# Altium schematic sheet Photodiode Receiver.SchDoc("Photodiode Receiver")
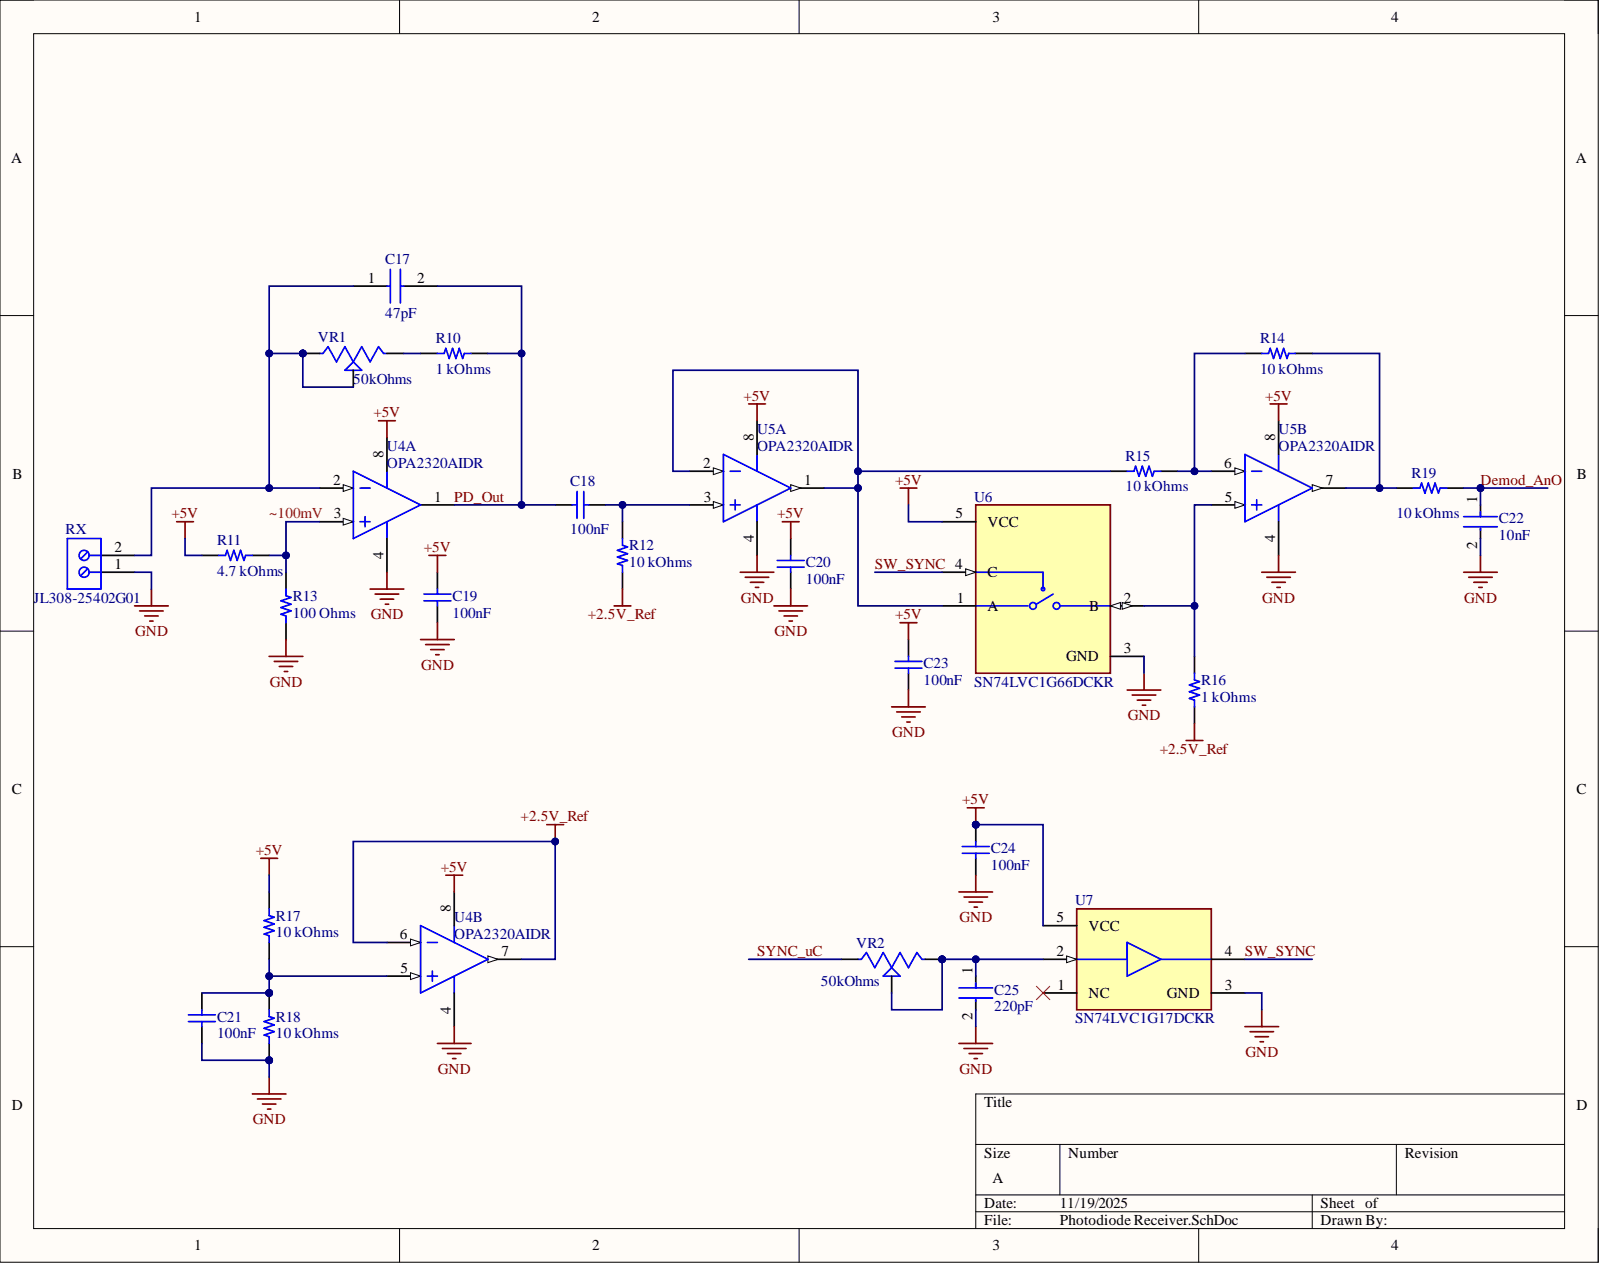
[diagram p0: Photodiode Receiver.SchDoc]

## Parts
C17  47pF
C18  100nF
C19  100nF
C20  100nF
C21  100nF
C22  10nF
C23  100nF
C24  100nF
C25  220pF
R10  1 kOhms
R11  4.7 kOhms
R12  10 kOhms
R13  100 Ohms
R14  10 kOhms
R15  10 kOhms
R16  1 kOhms
R17  10 kOhms
R18  10 kOhms
R19  10 kOhms
RX  JL308-25402G01
U4  OPA2320AIDR  (parts A,B)
U5  OPA2320AIDR  (parts A,B)
U6  SN74LVC1G66DCKR
U7  SN74LVC1G17DCKR
VR1  50kOhms
VR2  50kOhms

## Nets
+2.5V_Ref: R12.1 R16.2 U4.6 U4.7
+5V: C19.2 C20.2 C23.2 C24.2 R11.1 R17.2 U4.8 U5.8 U6.5(VCC) U7.5(VCC)
GND: C19.1 C20.1 C21.1 C22.2 C23.1 C24.1 C25.2 R13.1 R18.1 RX.1 U4.4 U5.4 U6.3(GND) U7.3(GND)
Demod_AnO: C22.1 R19.1
PD_Out: C17.2 C18.2 R10.1 U4.1
SW_SYNC: U6.4(C) U7.4
SYNC_uC: VR2.3
NetC17_1: C17.1 RX.2 U4.2 VR1.2 VR1.3
NetC18_1: C18.1 R12.2 U5.3
NetC21_2: C21.2 R17.1 R18.2 U4.5
NetC25_1: C25.1 U7.2 VR2.1 VR2.2
NetR10_2: R10.2 VR1.1
NetR11_2: R11.2 R13.2 U4.3
NetR14_1: R14.1 R19.2 U5.7
NetR14_2: R14.2 R15.1 U5.6
NetR15_2: R15.2 U5.1 U5.2 U6.1(A)
NetR16_1: R16.1 U5.5 U6.2(B)

Not connected: U7 1(NC)

## Library data of the parts
C17 = 0402CG470J500NT: Capacitor 0402CG470J500NT; Manufacturer_Name: Guangdong Fenghua Advanced Tech; Component Kind: Standard; Datasheet Link: https://datasheet.lcsc.com/szlcsc/Guangdong-Fenghua-Advanced-Tech-0402CG470J500NT_C1567.pdf; Height: 0.55mm; Manufacturer_Part_Number: 0402CG470J500NT
C18-C21 C23 C24 = CMP-2000-05439-1: Chip Capacitor, 100 nF, +/- 10%, 16 V, -55 to 125 degC, 0402 (1005 Metric), RoHS, Tape and Reel; Manufacturer: Samsung Electro-Mechanics; Capacitance: 100000pF; Dielectric: X7R; Rated Voltage: 16V; Case Size/Dimension: 0402; Component Kind: Standard; Datasheet URL: https://datasheet.ciiva.com/29198/458718-da-01-en-vs-kondensator-0402-29198423.pdf; Datasheet Version: Nov-10; Library Name: Altium Content Vault; Manufacturer URL: http://www.samsungsem.com/global/index.jsp; Tolerance (%): ±10%
C22 = CMP-13271-000387-1: Cap Ceramic 10nF 50V X7R ±10% SMD 0402 +125°C Paper T/R; Capacitance: 10nF; Case Code (Imperial): 0402; Case Code (Metric): 1005; Case/Package: 0402; Component Kind: Standard; Contact Plating: Tin; Depth: 0.5mm; Dielectric: X7R; Height: 0.5mm; Lead Free: Lead Free; Length: 1mm; Library Name: Altium Content Vault; Material: Ceramic; Max Operating Temperature: 125°C; Min Operating Temperature: -55°C; Mount: Surface Mount; Package Quantity: 1; Packaging: Tape and Reel; Radiation Hardening: No; Thickness: 0.022inch; Tolerance: 10%; Voltage: 50V; Voltage Rating: 50V; Voltage Rating (DC): 50V; Width: 0.02inch
C25 = CMP-13271-000087-1: Cap Ceramic 220pF 50V X7R ±10% Pad SMD 0603 +125°C T/R; Capacitance: 220pF; Case Code (Imperial): 0603; Case Code (Metric): 1608; Case/Package: 0603; Component Kind: Standard; Contact Plating: Tin; Depth: 0.8mm; Dielectric: X7R; Height: 0.8mm; Length: 1.6mm; Library Name: Altium Content Vault; Material: Ceramic; Max Operating Temperature: 125°C; Min Operating Temperature: -55°C; Mount: Surface Mount; Packaging: Tape and Reel; Radiation Hardening: No; Thickness: 0.035inch; Tolerance: 10%; Voltage: 50V; Voltage Rating: 50V; Width: 0.031inch
R10 R16 = CPF0402B1K0E: RES SMD 1K OHM 0.1% 1/16W 0402; Manufacturer: TE Connectivity Passive Product; Database Table Name: Resistors - Surface Mount - 0402; Power (Watts): 0.063W, 1/16W; Temperature Coefficient: ±25ppm/°C; Component Kind: Standard; ComponentLink1Description: Datasheet; ComponentLink1URL: https://www.te.com/commerce/DocumentDelivery/DDEController?Action=srchrtrv&DocNm=1773200&DocType=DS&DocLang=English; ComponentLink2Description: DigiKey Link; ComponentLink2URL: https://www.digikey.com/en/products/detail/te-connectivity-passive-product/CPF0402B1K0E/4966468; Composition: Thin Film; Device Package: 0402 (1005 Metric); Height - Seated (Max): 0.014" (0.35mm); LastUpdated: 2/9/2024 8:30:24 PM; Library Name: Celestial Altium Library - altium_library.DbLib; Lifecycle Status: Active; Minimum Order: 1; Number of Terminations: 2; Operating Temperature: -55°C ~ 155°C; Package / Case: 0402 (1005 Metric); Packaging: TapeAndReel; Part Number: CPF0402B1K0E; Part Status: Active; PartId: 2470; Price: 0.10; Resistance: 1 kOhms; Series: CPF, Neohm; Size / Dimension: 0.039" L x 0.020" W (1.00mm x 0.50mm); Supplier 1: DigiKey; Supplier Device Package: 0402; Supplier Part Number 1: A119928CT-ND; Tolerance: ±0.1%; Value: 1 kOhms
R11 = RR0510P-472-D: RES SMD 4.7K OHM 0.5% 1/16W 0402; Manufacturer: Susumu; Database Table Name: Resistors - Surface Mount - 0402; Power (Watts): 0.063W, 1/16W; Temperature Coefficient: ±25ppm/°C; Component Kind: Standard; ComponentLink1Description: Datasheet; ComponentLink1URL: http://www.susumu.co.jp/common/pdf/n_catalog_partition05_en.pdf; ComponentLink2Description: DigiKey Link; ComponentLink2URL: https://www.digikey.com/en/products/detail/susumu/RR0510P-472-D/432413; Composition: Thin Film; Device Package: 0402 (1005 Metric); Height - Seated (Max): 0.016" (0.40mm); LastUpdated: 2/9/2024 8:30:21 PM; Library Name: Celestial Altium Library - altium_library.DbLib; Lifecycle Status: Active; Minimum Order: 1; Number of Terminations: 2; Operating Temperature: -55°C ~ 125°C; Package / Case: 0402 (1005 Metric); Packaging: TapeAndReel; Part Number: RR0510P-472-D; Part Status: Active; PartId: 3209; Price: 0.01; Resistance: 4.7 kOhms; Series: RR; Size / Dimension: 0.039" L x 0.020" W (1.00mm x 0.50mm); Supplier 1: DigiKey; Supplier Part Number 1: RR05P4.7KDCT-ND; Tolerance: ±0.5%; Value: 4.7 kOhms
R12 R14 R15 R17-R19 = CRCW040210K0FKEE: RES SMD 10K OHM 1% 1/16W 0402; Manufacturer: Vishay Dale; Database Table Name: Resistors - Surface Mount - 0402; Power (Watts): 0.063W, 1/16W; Temperature Coefficient: ±100ppm/°C; Component Kind: Standard; ComponentLink1Description: Datasheet; ComponentLink1URL: http://www.vishay.com/docs/20035/dcrcwe3.pdf; ComponentLink2Description: DigiKey Link; ComponentLink2URL: https://www.digikey.com/en/products/detail/vishay-dale/CRCW040210K0FKEE/5069897; Composition: Thick Film; Device Package: 0402 (1005 Metric); Features: Automotive AEC-Q200; Height - Seated (Max): 0.016" (0.40mm); LastUpdated: 2/9/2024 8:30:20 PM; Library Name: Celestial Altium Library - altium_library.DbLib; Lifecycle Status: Active; Minimum Order: 1; Number of Terminations: 2; Operating Temperature: -55°C ~ 155°C; Package / Case: 0402 (1005 Metric); Packaging: CutTape; Part Number: CRCW040210K0FKEE; Part Status: Active; PartId: 3471; Price: 0.01; Ratings: AEC-Q200; Resistance: 10 kOhms; Series: CRCW; Size / Dimension: 0.039" L x 0.020" W (1.00mm x 0.50mm); Supplier 1: DigiKey; Supplier Device Package: 0402; Supplier Part Number 1: 541-2954-1-ND; Tolerance: ±1%; Value: 10 kOhms
R13 = RR0510P-101-D: RES SMD 100 OHM 0.5% 1/16W 0402; Manufacturer: Susumu; Database Table Name: Resistors - Surface Mount - 0402; Power (Watts): 0.063W, 1/16W; Temperature Coefficient: ±25ppm/°C; Component Kind: Standard; ComponentLink1Description: Datasheet; ComponentLink1URL: http://www.susumu.co.jp/common/pdf/n_catalog_partition05_en.pdf; ComponentLink2Description: DigiKey Link; ComponentLink2URL: https://www.digikey.com/en/products/detail/susumu/RR0510P-101-D/432375; Composition: Thin Film; Device Package: 0402 (1005 Metric); Height - Seated (Max): 0.016" (0.40mm); LastUpdated: 2/9/2024 8:30:21 PM; Library Name: Celestial Altium Library - altium_library.DbLib; Lifecycle Status: Active; Minimum Order: 1; Number of Terminations: 2; Operating Temperature: -55°C ~ 125°C; Package / Case: 0402 (1005 Metric); Packaging: TapeAndReel; Part Number: RR0510P-101-D; Part Status: Active; PartId: 3205; Price: 0.01; Resistance: 100 Ohms; Series: RR; Size / Dimension: 0.039" L x 0.020" W (1.00mm x 0.50mm); Supplier 1: DigiKey; Supplier Part Number 1: RR05P100DCT-ND; Tolerance: ±0.5%; Value: 100 Ohms
RX = CMP-1502-02456-2: Use comment for part number; NexarPartId: 105950649; Current Rating: 6A; Number of Contacts: 2; Voltage Rating: 150V; Component Kind: Standard; Contact Material: Tin; Height: 8.7mm; Library Name: Altium Content Vault; Max Operating Temperature: 105°C; Min Operating Temperature: -40°C; Number of Rows: 1
U4 U5 = CMP-0272-00771-3: Precision, 20 MHz, 0.9 pA Ib, RRIO, CMOS Operational Amplifier, 1.8 to 5.5 V, -40 to 125 degC, 8-pin SOIC (D8), Green (RoHS & no Sb/Br); Manufacturer: Texas Instruments; CMRR(Min)(dB): 100; IIB(Max)(pA): 400; Iq per channel(Max)(mA): 1.6; Number of Channels: 2; Offset Drift(Typ)(uV/C): 1.5; Slew Rate(Typ)(V/us): 10; Sub Family: High Output Current Amplifier (>=50mA), Low Input Bias Current Amp (<=10pA), Low Noise Amp (<=10nV/rtHz), Low Offset Voltage Amplifier (<=500uV), Low Supply Voltage Amplifier (<=2.7V), Precision Amplifier; Total Supply Voltage(Max)(+5V is 5, +/-5V is 10): 5.5; Total Supply Voltage(Min)(+5V is 5, +/-5V is 10): 1.8; Vio (25C)(Max)(mV): 0.15; Vn at 1kHz(Typ)(nV/rtHz): 8.5; Code_JEDEC: MS-012; Component Kind: Standard; ComponentLink1Description: Manufacturer URL; ComponentLink1URL: http://www.ti.com/; ComponentLink2Description: Datasheet; ComponentLink2URL: http://www.ti.com/general/docs/lit/getliterature.tsp?genericPartNumber=OPA2320&fileType=pdf; ComponentLink3Description: Package Specification; ComponentLink3URL: http://www.ti.com/litv/pdf/msoi002j; DatasheetVersion: SBOS513D; Eco-Plan: Green (RoHS & no Sb/Br); Library Name: Altium Content Vault; Mounting Technology: Surface Mount; PackageDescription: 8-Pin Plastic Small Outline, Body 4.9 x 3.9 mm, Pitch 1.27 mm; PackageReference: D0008A; PackageVersion: 4040047-3/M, 06/2011; PartNumber: OPA2320AIDR
U6 = CMP-0859-00385-4: IC SWITCH 1X1 SC70-5; Manufacturer: Texas Instruments; -3Db Bandwith: 300MHz; Max Junction Temp: +150°C; Max Supply Voltage: 5.5V; Min Supply Voltage: 1.65V; Mux Demux Ratio: 1:1; Nominal Supply Current: 1uA; Number Of Circuits: 1; On State Resistance: 5.5Ω; Standoff Height: 0mm; Throw Configuration: SPST-NO; Ambient Temperature Range High: +85°C; Ambient Temperature Range Low: -40°C; Automotive: No; Category: IC; Component Kind: Standard; ComponentLink2Description: Datasheet; ComponentLink2URL: http://www.ti.com/lit/ds/symlink/sn74lvc1g66.pdf; Device Class L1: Integrated Circuits (ICs); Device Class L2: Interface ICs; Device Class L3: Analog Switches; Digikey Description: IC SWITCH 1X1 SC70-5; Height: 1.1mm; Lead Free: Yes; Library Name: Altium Content Vault; Manufacturer Part Number: SN74LVC1G66DCKR; Mouser Description: Analogue Switch, Single Channel, SPST, 1, 10 ohm, 1.65V to 5.5V, SC-70, 5; Octopart: https://octopart.com/sn74lvc1g66dckr-texas+instruments-472215; Package: DCK0005A; RoHS: TRUE; Temperature: -40°C to +85°C; Temperature Range High: +85°C; Temperature Range Low: -40°C
U7 = CMP-0859-00388-5: IC BUF NON-INVERT 5.5V SC70-5; High Level Output Current: -32mA; Logic Function: Schmitt Trigger; Low Level Output Current: 32mA; Min Supply Voltage: 1.65V; Number of Bits: 1; Polarity: Non-Inverting; Propagation Delay: 11ns; Quiescent Current: 1.5uA; Case/Package: SC; Component Kind: Standard; Contact Plating: Gold; Lead Free: Lead Free; Library Name: Altium Content Vault; Max Operating Temperature: 125°C; Max Supply Voltage: 5.5V; Min Operating Temperature: -40°C; Mount: Surface Mount; Number of Bits per Element: 1; Number of Channels: 1; Number of Elements: 1; Number of Gates: 1; Number of Input Lines: 1; Number of Inputs: 1; Number of Output Lines: 1; Number of Outputs: 1; Number of Pins: 5; Package Quantity: 1; Packaging: Tape and Reel; Radiation Hardening: No; REACH SVHC: No SVHC; Termination: SMD/SMT; Turn-On Delay Time: 11ns
VR1 VR2 = 3314J-1-503E: Trimmer, Cermet, Rest 50 Kilohms, SMD, 1 Turn, Pwr-Rtg 0.25W | Bourns 3314J-1-503E; Check_prices: https://www.snapeda.com/parts/3314J-1-503E/Bourns/view-part/?ref=eda; Component Kind: Standard; Library Name: 3314J-1-503E.IntLib; MF: Bourns; MP: 3314J-1-503E; Package: NON STANDARD-4 Bourns; SnapEDA_Link: https://www.snapeda.com/parts/3314J-1-503E/Bourns/view-part/?ref=snap
